# Altium schematic sheet Power_Section.SchDoc
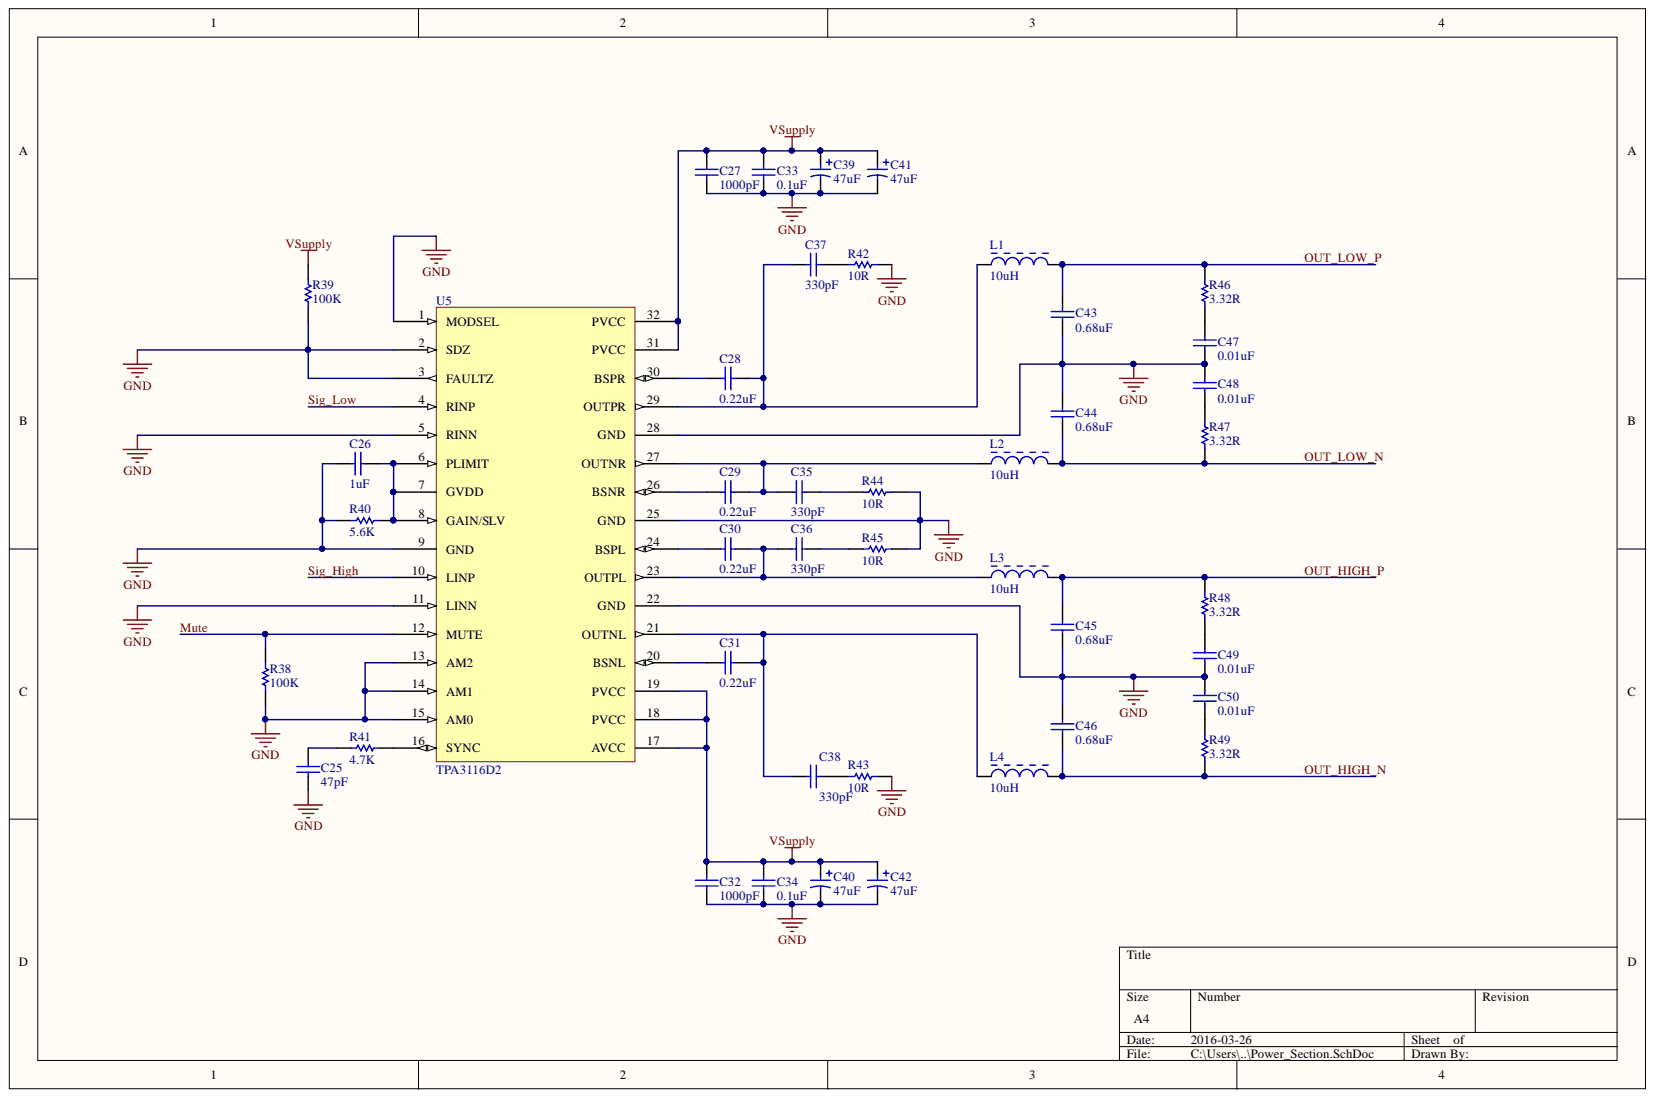
[diagram p0: Power_Section.SchDoc]

## Parts
C25  47pF  [CAPC2012X09N]
C26  1uF  [CAPC2012X09N]
C27  1000pF  [CAPC2012X09N]
C28  0.22uF  [CAPC1608X09N]
C29  0.22uF  [CAPC1608X09N]
C30  0.22uF  [CAPC1608X09N]
C31  0.22uF  [CAPC1608X09N]
C32  1000pF  [CAPC2012X09N]
C33  0.1uF  [CAPC2012X09N]
C34  0.1uF  [CAPC2012X09N]
C35  330pF  [CAPC1608X09N]
C36  330pF  [CAPC1608X09N]
C37  330pF  [CAPC1608X09N]
C38  330pF  [CAPC1608X09N]
C39  47uF
C40  47uF
C41  47uF
C42  47uF
C43  0.68uF  [CAP Radial 5mm]
C44  0.68uF  [CAP Radial 5mm]
C45  0.68uF  [CAP Radial 5mm]
C46  0.68uF  [CAP Radial 5mm]
C47  0.01uF  [CAPC2012X09N]
C48  0.01uF  [CAPC2012X09N]
C49  0.01uF  [CAPC2012X09N]
C50  0.01uF  [CAPC2012X09N]
L1  10uH  [IND_BOURNS_SRP00]
L2  10uH  [IND_BOURNS_SRP00]
L3  10uH  [IND_BOURNS_SRP00]
L4  10uH  [IND_BOURNS_SRP00]
R38  100K  [0603]
R39  100K  [0603]
R40  5.6K  [0603]
R41  4.7K  [0603]
R42  10R  [0805]
R43  10R  [0805]
R44  10R  [0805]
R45  10R  [0805]
R46  3.32R  [0603]
R47  3.32R  [0603]
R48  3.32R  [0603]
R49  3.32R  [0603]
U5  TPA3116D2  [DAP0032A]

## Nets
GND: C25.1 C26.1 C27.1 C32.1 C33.1 C34.1 C39.2 C40.2 C41.2 C42.2 C43.1 C44.2 C45.1 C46.2 C47.1 C48.2 C49.1 C50.2 R38.1 R39.1 R40.2 R42.2 R43.2 R44.2 R45.2 U5.1(MODSEL) U5.2(SDZ) U5.3(FAULTZ) U5.5(RINN) U5.9(GND) U5.11(LINN) U5.13(AM2) U5.14(AM1) U5.15(AM0) U5.22(GND) U5.25(GND) U5.28(GND) U5.33
VSUPPLY: C27.2 C32.2 C33.2 C34.2 C39.1 C40.1 C41.1 C42.1 R39.2 U5.17(AVCC) U5.18(PVCC) U5.19(PVCC) U5.31(PVCC) U5.32(PVCC)
MUTE: R38.2 U5.12(MUTE)
OUT_HIGH_N: C46.1 L4.2 R49.1
OUT_HIGH_P: C45.2 L3.2 R48.2
OUT_LOW_N: C44.1 L2.2 R47.1
OUT_LOW_P: C43.2 L1.2 R46.2
SIG_HIGH: U5.10(LINP)
SIG_LOW: U5.4(RINP)
NetC25_2: C25.2 R41.1
NetC26_2: C26.2 R40.1 U5.6(PLIMIT) U5.7(GVDD) U5.8(GAIN/SLV)
NetC28_1: C28.1 U5.30(BSPR)
NetC28_2: C28.2 C37.1 L1.1 U5.29(OUTPR)
NetC29_1: C29.1 U5.26(BSNR)
NetC29_2: C29.2 C35.1 L2.1 U5.27(OUTNR)
NetC30_1: C30.1 U5.24(BSPL)
NetC30_2: C30.2 C36.1 L3.1 U5.23(OUTPL)
NetC31_1: C31.1 U5.20(BSNL)
NetC31_2: C31.2 C38.1 L4.1 U5.21(OUTNL)
NetC35_2: C35.2 R44.1
NetC36_2: C36.2 R45.1
NetC37_2: C37.2 R42.1
NetC38_2: C38.2 R43.1
NetC47_2: C47.2 R46.1
NetC48_1: C48.1 R47.2
NetC49_2: C49.2 R48.1
NetC50_1: C50.1 R49.2
NetR41_2: R41.2 U5.16(SYNC)

## Library data of the parts
C25 = CAP CER 47pF 0603: Component Kind: Standard; Description: CAP CER 47pF 0603; Digikey Part Number: 1276-1037-1-ND; Value: 47pF; Library Name: Speaker Library.SchLib
C26 = CAP CER 1uF 0805: Component Kind: Standard; Description: CAP CER 1uF 0805; Digikey Part Number: 587-2910-1-ND; Value: 1uF; Library Name: Speaker Library.SchLib
C27 C32 = CAP CER 1000pF 0805: Component Kind: Standard; Digikey Part Number: 399-1147-1-ND; Value: 1000pF; Library Name: Speaker Library.SchLib
C28-C31 = CAP CER 0.22uF 0603: Component Kind: Standard; Digikey Part Number: 445-7408-1-ND; Value: 0.22uF; Library Name: Speaker Library.SchLib
C33 C34 = CAP CER 0.1uF 0805: Component Kind: Standard; Digikey Part Number: 1276-1003-1-ND; Value: 0.1uF; Library Name: Speaker Library.SchLib
C35-C38 = CAP CER 330pF 0603: Component Kind: Standard; Digikey Part Number: 490-1439-1-ND; Value: 330pF; Library Name: Speaker Library.SchLib
C39-C42 = CAP ALUM 47uF SMD: Component Kind: Standard; Digikey Part Number: PCE3842CT-ND; Value: 47uF; Library Name: Speaker Library.SchLib
C43-C46 = CAP 0.68uF Radial 5mm: Manufacturer: EPCOS (TDK); Voltage: 63V; Component Kind: Standard; Digikey Part Number: 495-1114-ND; Value: 0.68uF; Library Name: Speaker Library.SchLib
C47-C50 = CAP CER 0.01uF 0805: Component Kind: Standard; Digikey Part Number: 490-1652-1-ND; Value: 0.01uF; Library Name: Speaker Library.SchLib
L1-L4 = IND 10uH 10A SMD: Component Kind: Standard; Digikey Part Number: SRP1270-100MCT-ND; Value: 10uH; Library Name: Speaker Library.SchLib
R38 R39 = RES 100K 0603: Power: 0.1W; Tolerance: 1%; Component Kind: Standard; Value: 100K; Library Name: Speaker Library.SchLib
R40 = RES 5.6K 0603: Tolerance: 1%; Component Kind: Standard; Type: RES; Value: 5.6K; Library Name: Speaker Library.SchLib
R41 = RES 4.7K 0603: Tolerance: 1%; Component Kind: Standard; Type: RES; Value: 4.7K; Library Name: Speaker Library.SchLib
R42-R45 = RES 10R 0805: Power: 0.25W; Tolerance: 1%; Component Kind: Standard; Digikey Part Number: RNCP0805FTD10R0CT-ND; Type: RES; Value: 10R; Library Name: Speaker Library.SchLib
R46-R49 = RES 3.32R 0603: Power: 0.1W; Tolerance: 1%; Component Kind: Standard; Digikey Part Number: 541-3.32HHCT-ND; Type: RES; Value: 3.32R; Library Name: Speaker Library.SchLib
U5 = TPA3116D2: Component Kind: Standard; Digikey Part Number: 296-39337-1-ND; Library Name: Speaker Library.SchLib
